# Altium schematic sheet 4_POWER.SchDoc(4_POWER)
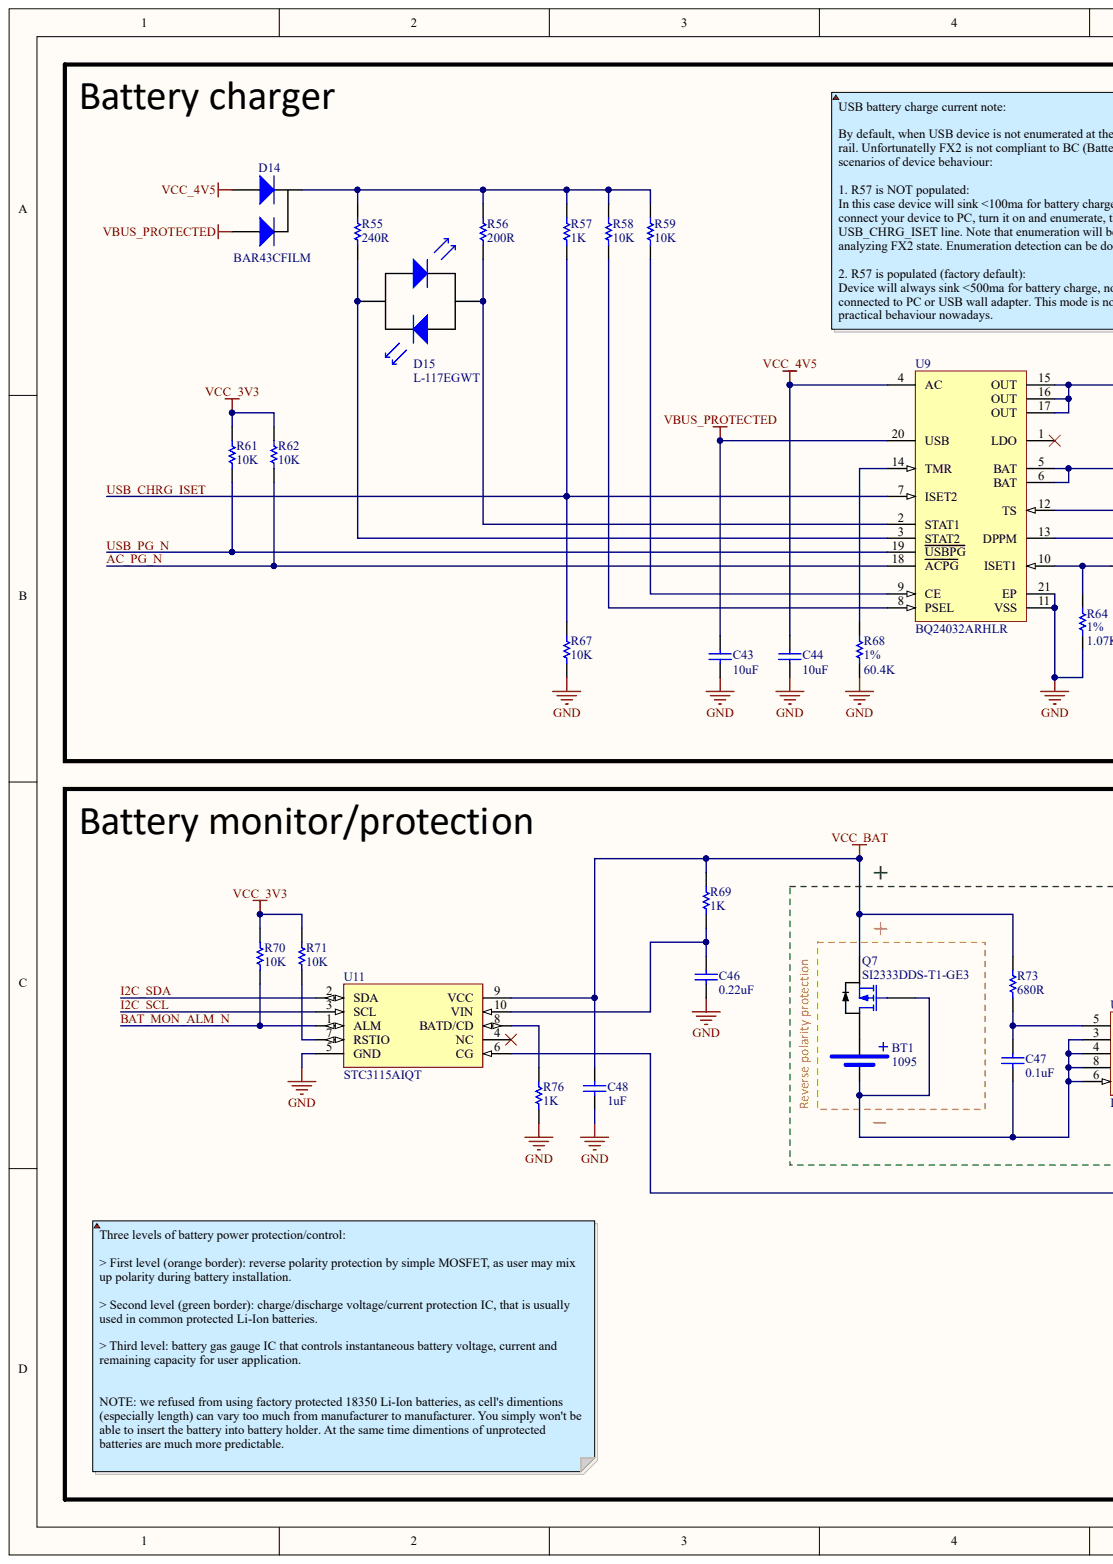
[diagram p0: 4_POWER.SchDoc, left]
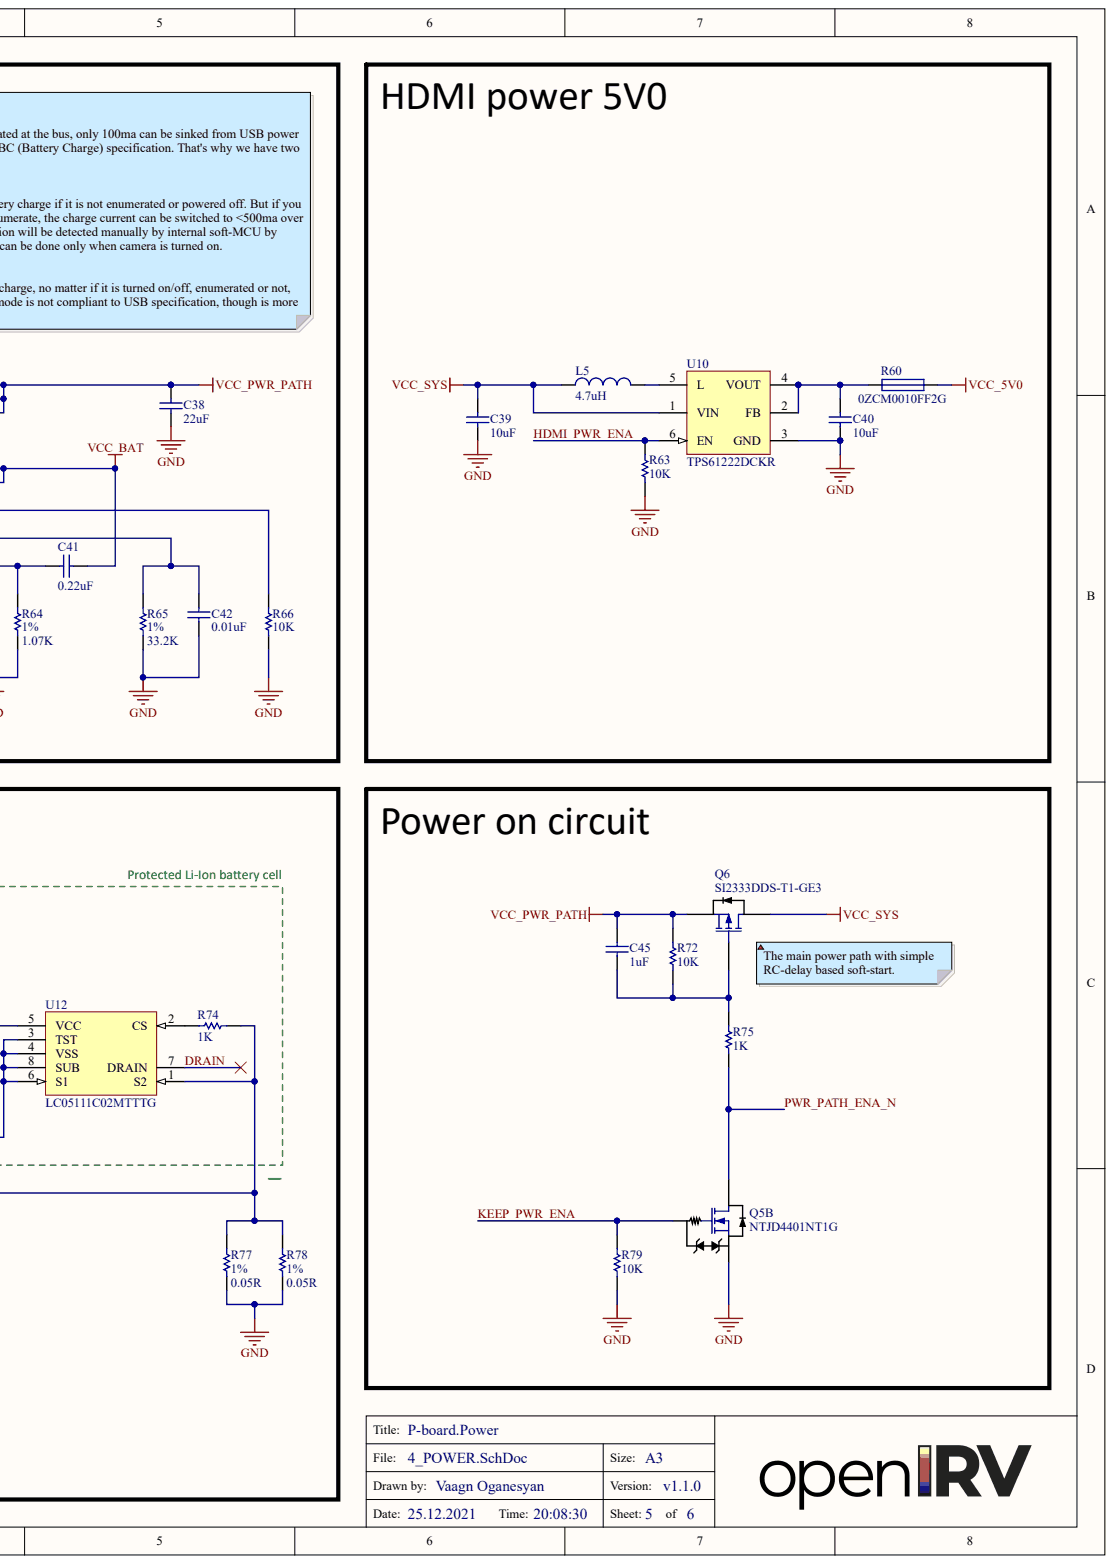
[diagram p1: 4_POWER.SchDoc, right]

## Parts
BT1  1095  [1095]
C38  22uF  [C1206[3216]]
C39  10uF  [C0805[2012]]
C40  10uF  [C0805[2012]]
C41  0.22uF  [C0603[1608]]
C42  0.01uF  [C0402[1005]]
C43  10uF  [C0805[2012]]
C44  10uF  [C0805[2012]]
C45  1uF  [C0603[1608]]
C46  0.22uF  [C0603[1608]]
C47  0.1uF  [C0402[1005]]
C48  1uF  [C0603[1608]]
D14  BAR43CFILM  [BAR43CFILM]
D15  L-117EGWT  [LED_AXIAL[7x5x2]]
L5  value 4.7uH  [L0805[2012]]  (hidden comment: LQM21PN4R7MGRD)
Q5  NTJD4401NT1G  [NTJD4401NT1G]  (part B)
Q6  SI2333DDS-T1-GE3  [SI2333DDS-T1-GE3]
Q7  SI2333DDS-T1-GE3  [SI2333DDS-T1-GE3]
R55  240R  [R0603[1608]]
R56  200R  [R0603[1608]]
R57  1K  [R0402[1005]]
R58  10K  [R0402[1005]]
R59  10K  [R0402[1005]]
R60  0ZCM0010FF2G  [R0603[1608]]
R61  10K  [R0402[1005]]
R62  10K  [R0402[1005]]
R63  10K  [R0402[1005]]
R64  1.07K  Tolerance: 1%  [R0603[1608]]
R65  33.2K  Tolerance: 1%  [R0603[1608]]
R66  10K  [R0402[1005]]
R67  10K  [R0402[1005]]
R68  60.4K  Tolerance: 1%  [R0603[1608]]
R69  1K  [R0402[1005]]
R70  10K  [R0402[1005]]
R71  10K  [R0402[1005]]
R72  10K  [R0402[1005]]
R73  680R  [R0603[1608]]
R74  1K  [R0402[1005]]
R75  1K  [R0402[1005]]
R76  1K  [R0402[1005]]
R77  0.05R  Tolerance: 1%  [R1206[3216]]
R78  0.05R  Tolerance: 1%  [R1206[3216]]
R79  10K  [R0402[1005]]
U9  BQ24032ARHLR  [BQ24032ARHLR]
U10  TPS61222DCKR  [TPS61222DCKR]
U11  STC3115AIQT  [STC3115AIQT]
U12  LC05111C02MTTTG  [LC05111C02MTTTG]

## Nets
GND: C38.1 C39.1 C40.1 C42.1 C43.1 C44.1 C46.1 C48.2 Q5.4 R63.2 R64.2 R65.2 R66.1 R67.2 R68.2 R76.1 R77.2 R78.2 R79.1 U9.11(VSS) U9.21(EP) U10.3(GND) U11.5(GND)
VBUS_PROTECTED: C43.2 D14.2 U9.20(USB)
VCC_3V3: R61.2 R62.2 R70.2 R71.2
VCC_4V5: C44.2 D14.1 U9.4(AC)
VCC_5V0: R60.2
VCC_BAT: C41.2 C48.1 Q7.2 R69.2 R73.1 U9.5(BAT) U9.6(BAT) U11.9(VCC)
VCC_PWR_PATH: C38.2 C45.1 Q6.2 R72.1 U9.15(OUT) U9.16(OUT) U9.17(OUT)
VCC_SYS: C39.2 L5.1 Q6.3 U10.1(VIN)
AC_PG_N: R62.1 U9.18(ACPG)
BAT_MON_ALM_N: R70.1 U11.1(ALM)
DRAIN: U12.7(DRAIN)
HDMI_PWR_ENA: R63.1 U10.6(EN)
I2C_SCL: U11.3(SCL)
I2C_SDA: U11.2(SDA)
KEEP_PWR_ENA: Q5.5 R79.2
PWR_PATH_ENA_N: Q5.3 R75.2
USB_CHRG_ISET: R57.1 R67.1 U9.7(ISET2)
USB_PG_N: R61.1 U9.19(USBPG)
NetBT1_1: BT1.1 Q7.3
NetBT1_2: BT1.2 C47.2 Q7.1 U12.3(TST) U12.4(VSS) U12.6(S1) U12.8(SUB)
NetC40_2: C40.2 R60.1 U10.2(FB) U10.4(VOUT)
NetC41_1: C41.1 R64.1 U9.10(ISET1)
NetC42_2: C42.2 R65.1 U9.13(DPPM)
NetC45_2: C45.2 Q6.1 R72.2 R75.1
NetC46_2: C46.2 R69.1 U11.10(VIN)
NetC47_1: C47.1 R73.2 U12.5(VCC)
NetD14_3: D14.3 R55.1 R56.1 R57.2 R58.2 R59.2
NetD15_1: D15.1 R55.2 U9.3(STAT2)
NetD15_2: D15.2 R56.2 U9.2(STAT1)
NetL5_2: L5.2 U10.5(L)
NetR58_1: R58.1 U9.8(PSEL)
NetR59_1: R59.1 U9.9(CE)
NetR66_2: R66.2 U9.12(TS)
NetR68_1: R68.1 U9.14(TMR)
NetR71_1: R71.1 U11.7(RSTIO)
NetR74_1: R74.1 U12.2(CS)
NetR74_2: R74.2 R77.1 R78.1 U11.6(CG) U12.1(S2)
NetR76_2: R76.2 U11.8(BATD/CD)

Not connected: U9 1(LDO); U11 4(NC)

## Library data of the parts
C38-C40 C43 C44 = CAP: Dielectric: X7R; Tolerance: 10%; Voltage: 10V; Component Kind: Standard; Library Name: general.IntLib; Mounting: SMD
  C38: Value: 22uF
  C39 C40 C43 C44: Value: 10uF
C41 C45 C46 C48 = CAP: Dielectric: X7R; Tolerance: 10%; Voltage: 25V; Component Kind: Standard; Library Name: general.IntLib; Mounting: SMD
  C41 C46: Value: 0.22uF
  C45 C48: Value: 1uF
C42 C47 = CAP: Dielectric: X7R; Tolerance: 10%; Voltage: 50V; Component Kind: Standard; Library Name: general.IntLib; Mounting: SMD
  C42: Value: 0.01uF
  C47: Value: 0.1uF
D14 = Diode_2: Component Kind: Standard; Library Name: general.IntLib
D15 = LED_DUAL: Component Kind: Standard; Library Name: general.IntLib; ManufacturerPartNumber: L-117EGWT
L5 = Inductor: Component Kind: Standard; Library Name: general.IntLib; ManufacturerPartNumber: LQM21PN4R7MGRD
Q5 = NTJD4401NT1G: Dual N-channel mosfet; Component Kind: Standard; Library Name: general.IntLib
R55 R56 = RES: Power: 0.1W; Tolerance: 5%; Component Kind: Standard; Library Name: general.IntLib; Mounting: SMD
  R55: Value: 240R
  R56: Value: 200R
R57-R59 R61-R63 R66 R67 R69-R72 R74-R76 R79 = RES: Power: 0.06W; Tolerance: 5%; Component Kind: Standard; Library Name: general.IntLib; Mounting: SMD
  R57 R69 R74-R76: Value: 1K
  R58 R59 R61-R63 R66 R67 R70-R72 R79: Value: 10K
R60 = Fuse: Current: 0.1A; Component Kind: Standard; Library Name: general.IntLib; ManufacturerPartNumber: 0ZCM0010FF2G; Mounting: SMD
R64 R65 R68 = RES: Power: 0.1W; Component Kind: Standard; Library Name: general.IntLib; Mounting: SMD
  R64: Value: 1.07K
  R65: Value: 33.2K
  R68: Value: 60.4K
R73 = RES: Power: 0.1W; Tolerance: 1%; Component Kind: Standard; Library Name: general.IntLib; Mounting: SMD; Value: 680R
R77 R78 = RES: Power: 0.25W; Component Kind: Standard; Library Name: general.IntLib; Mounting: SMD; Value: 0.05R
U9 = BQ24032ARHLR: Dual Input Li-Ion Charger with Dynamic Power Path, Output regulated to 4.4 V, Vbat 4.2 V, 4.3 V, -40 to 85 degC, 20-pin QFN (RHL), Green (RoHS & no Sb/Br); Manufacturer: Texas Instruments; Lead Acid: N; Li-Ion: Y; SubFamily: Battery Charge Management; Vin(Min)(V): 4.3; Component Kind: Standard; ComponentLink1Description: Datasheet; ComponentLink1URL: http://www.ti.com/general/docs/lit/getliterature.tsp?genericPartNumber=BQ24032A&fileType=pdf; DatasheetVersion: SLUS618H; Eco-Plan: Green (RoHS & no Sb/Br); Li-Polymer: Y; Library Name: general.IntLib; Mounting Technology: Surface Mount; NiCd: N; NiMH: N; Over Voltage Protection: N; PackageDescription: 20-Leads Plastic Quad Flatpack No-Lead, 4.5 x 3.5 x 0.9 mm Body, 0.5 mm Pitch, Exposed Pad; PackageReference: RHL20-3050X2050TP; PackageVersion: revJ, Dec-2011; PartNumber: BQ24032ARHLR; Supplier 1: Digi-Key; Supplier 2: Digi-Key; Supplier 3: Digi-Key; Supplier 4: Newark; Supplier 5: Mouser; Supplier Part Number 1: 296-18285-2-ND; Supplier Part Number 2: 296-18285-1-ND; Supplier Part Number 3: 296-18285-6-ND; Supplier Part Number 4: 43K9063; Supplier Part Number 5: 595-BQ24032ARHLR
U10 = TPS61222DCKR: DC-DC Boost converter; Component Kind: Standard; Library Name: general.IntLib
U11 = STC3115AIQT: Gas gauge IC with alarm output for handheld applications; Component Kind: Standard; Library Name: general.IntLib
U12 = LC05111C02MTTTG: 1-Cell Lithium-Ion Battery Protection IC with Integrated Power MOSFET; Component Kind: Standard; Library Name: general.IntLib
BT1 = 1095: Component Kind: Standard; Library Name: general.IntLib
Q6 Q7 = SI2333DDS-T1-GE3: Component Kind: Standard; Library Name: general.IntLib
